# Altium schematic sheet Central PCB.SchDoc
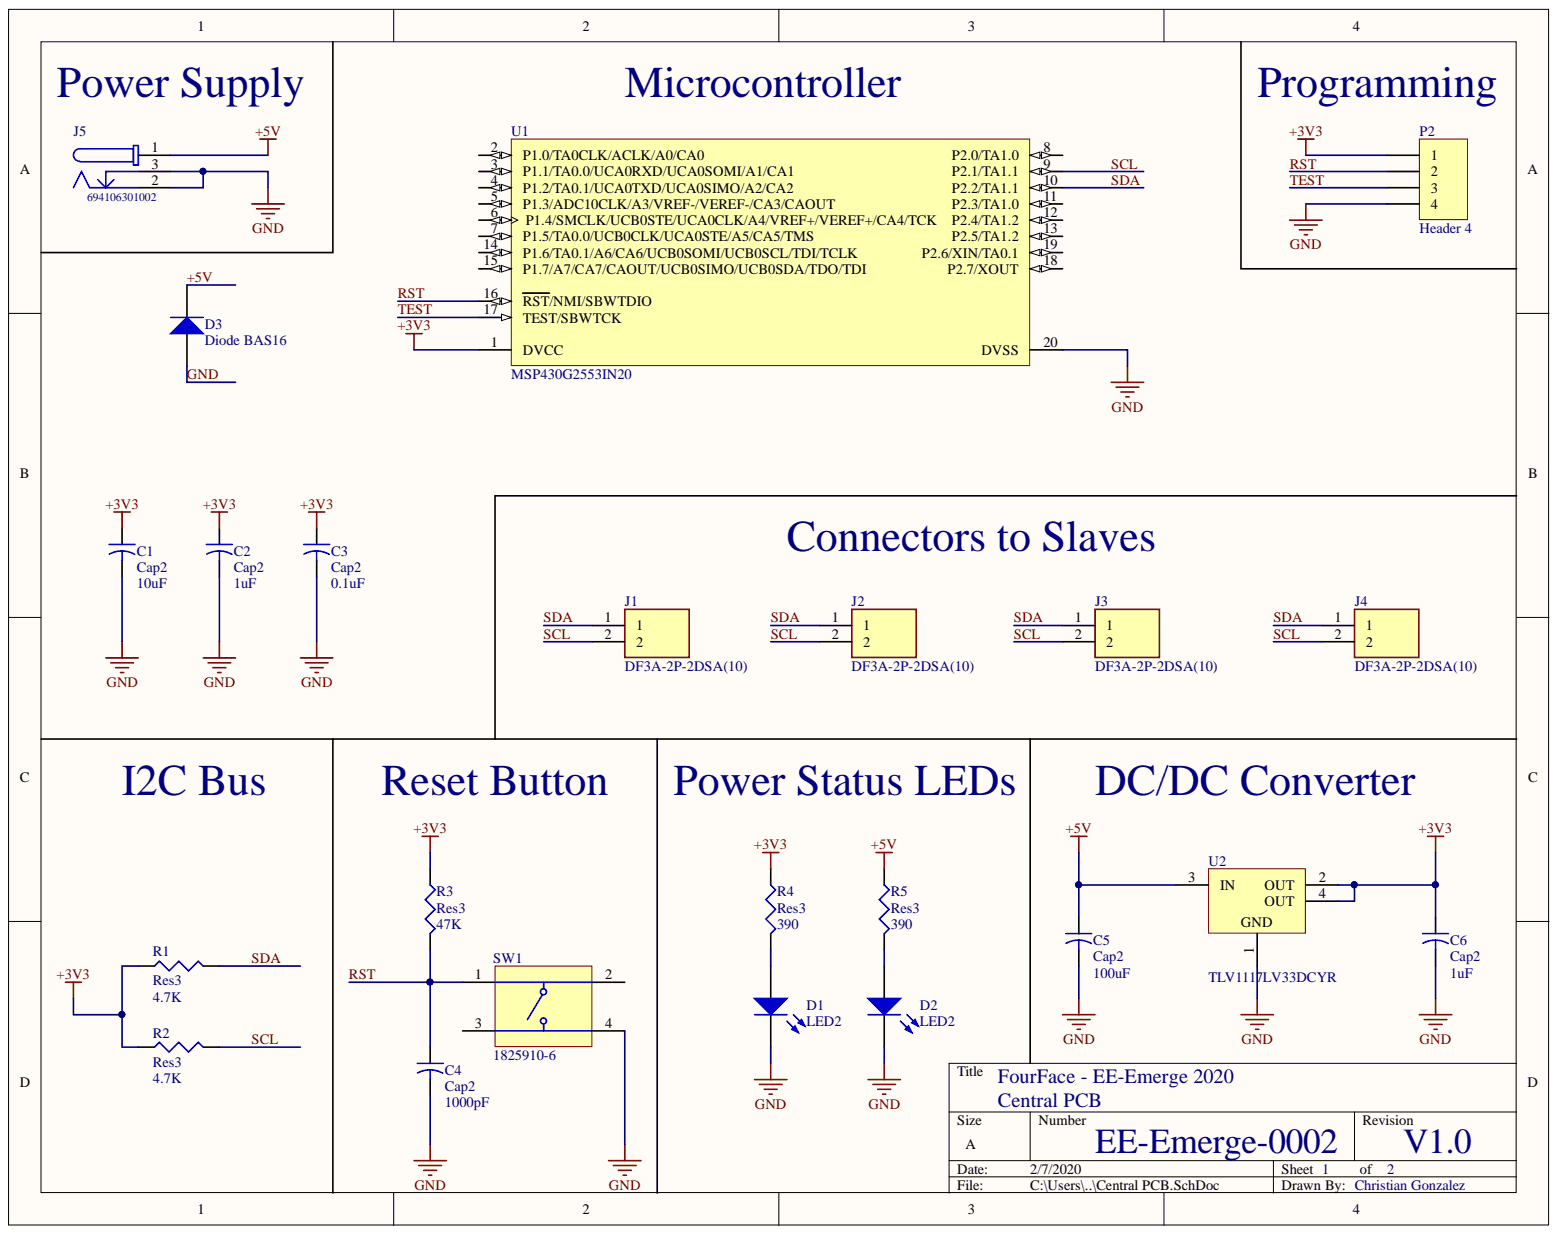
[diagram p0: Central PCB.SchDoc]

## Parts
C1  Cap2  value 10uF  [C0805]
C2  Cap2  value 1uF  [C0805]
C3  Cap2  value 0.1uF  [C0805]
C4  Cap2  value 1000pF  [C0805]
C5  Cap2  value 100uF  [C0805]
C6  Cap2  value 1uF  [C0805]
D1  LED2  [3.2X1.6X1.1]
D2  LED2  [3.2X1.6X1.1]
D3  Diode BAS16  [SOT-23_N]
J1  DF3A-2P-2DSA(10)  [SHDR2W50P0X200_1X2_600X500X500P]
J2  DF3A-2P-2DSA(10)  [SHDR2W50P0X200_1X2_600X500X500P]
J3  DF3A-2P-2DSA(10)  [SHDR2W50P0X200_1X2_600X500X500P]
J4  DF3A-2P-2DSA(10)  [SHDR2W50P0X200_1X2_600X500X500P]
J5  694106301002  [694106301002]
P2  Header 4  [HDR1X4]
R1  Res3  value 4.7K  [6-0805_N]
R2  Res3  value 4.7K  [6-0805_N]
R3  Res3  value 47K  [6-0805_N]
R4  Res3  value 390  [6-0805_N]
R5  Res3  value 390  [6-0805_N]
SW1  1825910-6  [TECO-1825910-6_V]
U1  MSP430G2553IN20  [N0020A]
U2  TLV1117LV33DCYR  [DCY0004A_N]

## Nets
+3V3: C1.1 C2.1 C3.1 C6.1 P2.1 R1.1 R2.1 R3.1 R4.1 U1.1(DVCC) U2.2(OUT) U2.4(OUT)
+5V: C5.1 D3.3 J5.1 R5.1 U2.3(IN)
GND: C1.2 C2.2 C3.2 C4.2 C5.2 C6.2 D1.2 D2.2 D3.1 J5.2 J5.3 P2.4 SW1.4 U1.20(DVSS) U2.1
RST: C4.1 P2.2 R3.2 SW1.1 U1.16(RST/NMI/SBWTDIO)
SCL: J1.2 J2.2 J3.2 J4.2 R2.2 U1.9(P2.1/TA1.1)
SDA: J1.1 J2.1 J3.1 J4.1 R1.2 U1.10(P2.2/TA1.1)
TEST: P2.3 U1.17(TEST/SBWTCK)
NetD1_1: D1.1 R4.2
NetD2_1: D2.1 R5.2

Not connected: SW1 2 3; U1 2(P1.0/TA0CLK/ACLK/A0/CA0) 3(P1.1/TA0.0/UCA0RXD/UCA0SOMI/A1/CA1) 4(P1.2/TA0.1/UCA0TXD/UCA0SIMO/A2/CA2) 5(P1.3/ADC10CLK/A3/VREF-/VEREF-/CA3/CAOUT) 6(P1.4/SMCLK/UCB0STE/UCA0CLK/A4/VREF+/VEREF+/CA4/TCK) 7(P1.5/TA0.0/UCB0CLK/UCA0STE/A5/CA5/TMS) 8(P2.0/TA1.0) 11(P2.3/TA1.0) 12(P2.4/TA1.2) 13(P2.5/TA1.2) 14(P1.6/TA0.1/A6/CA6/UCB0SOMI/UCB0SCL/TDI/TCLK) 15(P1.7/A7/CA7/CAOUT/UCB0SIMO/UCB0SDA/TDO/TDI) 18(P2.7/XOUT) 19(P2.6/XIN/TA0.1)

## Library data of the parts
C1 C3-C6 = Cap2: Capacitor; Component Kind: Standard; PackageDescription: Capacitor; 2 Leads; PackageReference: CAPR5-4X5; Published: 23-Sep-2002; Signal Integrity: Cap; Simulation: CAP; Publisher: Altium Limited
  C5 C6: Library Name: PCB_Project_2.IntLib
  C1 C3 C4: Library Name: EE_Emerge_SkillsProject.SCHLIB
C2 = Cap2_4: Capacitor; Component Kind: Standard; PackageDescription: Capacitor; 2 Leads; PackageReference: CAPR5-4X5; Published: 23-Sep-2002; Signal Integrity: Cap; Simulation: CAP; Library Name: EE_Emerge_SkillsProject.SCHLIB; Publisher: Altium Limited
D1 D2 = LED2: Typical RED, GREEN, YELLOW, AMBER GaAs LED; Component Kind: Standard; LatestRevisionDate: 17-Jul-2002; LatestRevisionNote: Re-released for DXP Platform.; Library Name: PCB_Project_2.IntLib; PackageDescription: SM LED; 2 Flat Leads; PackageReference: 3.2X1.6X1.1; PackageVersion: Feb-2002; Published: 8-Jun-2000; Simulation: LED2; Publisher: Altium Limited
D3 = Diode BAS16: Silicon Switching Diode for High-Speed Switching; Note: Pin 2 is not connected and not drawn.; Component Kind: Standard; ComponentLink1Description: Manufacturer Link; ComponentLink1URL: http://www.infineon.com/; ComponentLink2Description: Datasheet; ComponentLink2URL: http://www.infineon.com/upload/Document/cmc_upload/documents/012/5331/bas16series.pdf; DatasheetVersion: Oct-1999; LatestRevisionDate: 29-May-2009; LatestRevisionNote: IPC-7351 Footprint Added.; Library Name: Miscellaneous Devices.IntLib; PackageDescription: 3-Pin SOT-23 Package 0.95 mm Pitch, 2.5 mm Lead Span; PackageReference: SOT-23; PackageVersion: Sep-2001; Published: 21-Mar-2003; Simulation: BAS16; Publisher: Altium Limited
J1-J4 = DF3A-2P-2DSA(10): Connector; Manufacturer_Name: Hirose; Component Kind: Standard; Datasheet Link: https://componentsearchengine.com/Datasheets/1/DF3A-2P-2DSA(10).pdf; Height: 5mm; Manufacturer_Part_Number: DF3A-2P-2DSA(10); Mouser Part Number: 798-DF3A-2P-2DSA10; Mouser Price/Stock: https://www.mouser.com/Search/Refine.aspx?Keyword=798-DF3A-2P-2DSA10
J5 = CMP-1502-00188-1: DC Power Jack Connector WR-DC, Male, Right Angled, THT, 5 A, 24 V; Manufacturer: Wurth Elektronik; Opening Diameter: 6.4mm; Plug Inner Diameter: 2.05mm; Plug Outer Diameter: 5.5mm; Rated Current: 5A; Working Voltage: 24V; Component Kind: Standard; Datasheet URL: http://katalog.we-online.de/em/datasheet/6941xx301002.pdf; Gender: Male; Library Name: Altium Content Vault; Manufacturer URL: http://www.we-online.de; Mounting Technology: THT; Operating Temperature: -40to85degC; Orientation: RightAngled; Package Reference: 694106301002; Packaging: Bag; Part Number: 694106301002; Publisher: WurthElektronik
P2 = Header 4: Header, 4-Pin; Component Kind: Standard; LatestRevisionDate: 17-Jul-2002; LatestRevisionNote: Re-released for DXP Platform.; Library Name: Miscellaneous Connectors.IntLib; Signal Integrity: Connector; Publisher: Altium Limited
R1-R5 = Res3: Resistor; Component Kind: Standard; LatestRevisionDate: 29-May-2009; LatestRevisionNote: IPC-7351 Footprint Added.; PackageDescription: Chip Resistor; PackageReference: J1-0603; Published: 8-Jun-2000; Signal Integrity: Res; Simulation: RESISTOR; Library Name: EE_Emerge_SkillsProject.SCHLIB; Publisher: Altium Limited
SW1 = CMP-1684-00021-1: Tact Switch, SPST-NO, 0.05 A, -35 to 85 degC, 4-Pin THD, RoHS, Bulk; Manufacturer: TE Connectivity; Actuator Color: Black; Actuator Length: 1.4mm; Actuator Material: PolybutyleneTerephthalate; Actuator Style: RoundButton; Body Orientation: Straight; Bushing Type: Unthreaded; Contact Rating @ Voltage: 0.05A@24VDC; Dielectric Strength: 500VAC; Electrical Life: 100000Cycles; Function: MomentaryContact; Illumination: N/R; Maximum Contact Resistance: 100mOhm; Maximum DC Voltage Rating: 24V; Pole Throw Configuration: SPST; Switch Configuration: OFF(ON); Base Material: N/A; Component Kind: Standard; Contact Material: CopperAlloy; Contact Plating: Silver; Datasheet URL: http://www.te.com/commerce/DocumentDelivery/DDEController?Action=showdoc&DocId=Customer+Drawing%7F1825910%7FC9%7Fpdf%7FEnglish%7FENG_CD_1825910_C9.pdf%7F1825910-6; Datasheet Version: Rev. C9; Dimension: 5.99x5mm; Family: 1825910; Housing Material: N/A; Library Name: Altium Content Vault; Manufacturer URL: http://www.te.com; Maximum AC Voltage Rating: N/A; Mechanical Life: 100000Cycles; Minimum Insulation Resistance: 100MOhm; Mounting: ThroughHole; Operating Temperature: -35to85C; Package Description: 4-Pin Through Hole Device, Body 5.99 x 5.99 mm, Pitch 6.5 mm; Package Reference: THD; Packaging: N/A; Part No: 1825910-6; Product Weight: N/A; RoHS: Yes; Seal Description: N/A; Seal Location: N/A; Terminal Type: PCPins; Thread Size: N/R
U1 = CMP-0365-00184-2: 16 MHz Mixed Signal Microcontroller with 16 KB Flash, 512 B SRAM and 24 GPIOs, -40 to 85 degC, 20-pin DIP (N), Pb-Free (RoHS); Manufacturer: Texas Instruments; ADC: 10-bit SAR; ADC Channels: 8; Brown Out Reset: Yes; Flash(KB): 16; GPIO: 24; SRAM(B): 512; SubFamily: Value Line; Timers - 16-bit: 2; USCI_A (UART/LIN/IrDA/SPI): 1; Cap touch I/O: Yes; Code_JEDEC: MS-001; Comparators: Yes; Component Kind: Standard; ComponentLink1Description: Manufacturer URL; ComponentLink1URL: http://www.ti.com/; ComponentLink2Description: Datasheet; ComponentLink2URL: http://www.ti.com/general/docs/lit/getliterature.tsp?genericPartNumber=MSP430G2553&fileType=pdf; ComponentLink3Description: Package Specification; ComponentLink3URL: http://www.ti.com/litv/pdf/mpds177g; DatasheetVersion: SLAS735G; Eco-Plan: Pb-Free (RoHS); Frequency(MHz): 16; Library Name: PCB_Project_2.IntLib; Manufacturer 1: Texas Instruments; Manufacturer Part Number 1: MSP430G2553IN20; Mounting Technology: Through Hole; PackageDescription: 20-Pin Plastic In-Line, Body 25.40 x 6.35 mm, Pitch 2.54 mm; PackageReference: N0020A; PackageVersion: 4040049/E; PartNumber: MSP430G2553IN20; Temp Sensor: Yes; USCI_B (I2C & SPI): 1; Watchdog: Yes
U2 = CMP-0395-00503-2: Single Output LDO, 1 A, Fixed 3.3 V Output, 2 to 5.5 V Input, 4-pin SOT-223 (DCY), -40 to 125 degC, Green (RoHS & no Sb/Br); Manufacturer: Texas Instruments; Accuracy(%): 2; Fixed Output Options(V): 3.3; Iout(Max)(A): 1; Iq(Typ)(mA): 0.05; SubFamily: Single Channel LDO; Vdo(Typ)(mV): 1200; Vin(Max)(V): 5.5; Code_JEDEC: TO-261; Component Kind: Standard; ComponentLink1Description: Manufacturer URL; ComponentLink1URL: http://www.ti.com/; ComponentLink2Description: Datasheet; ComponentLink2URL: http://www.ti.com/general/docs/lit/getliterature.tsp?genericPartNumber=TLV1117LV33&fileType=pdf; ComponentLink3Description: Package Specification; ComponentLink3URL: http://www.ti.com/litv/pdf/mpds094a; DatasheetVersion: SBVS160A; Eco-Plan: Green (RoHS & no Sb/Br); Library Name: Altium Content Vault; Mounting Technology: Surface Mount; PackageDescription: 4-Pin Plastic Small Outline, Body 3.5 x 6.5 mm, Pitch 2.3 mm; PackageReference: DCY0004A; PackageVersion: 4202506/B; PartNumber: TLV1117LV33DCYR; Vin(Min)(V): 2; Vout Adj(Max)(V): 3.3; Vout Adj(Min)(V): 3.3
